# Altium schematic sheet SIm7600x.SchDoc(SIm7600x)
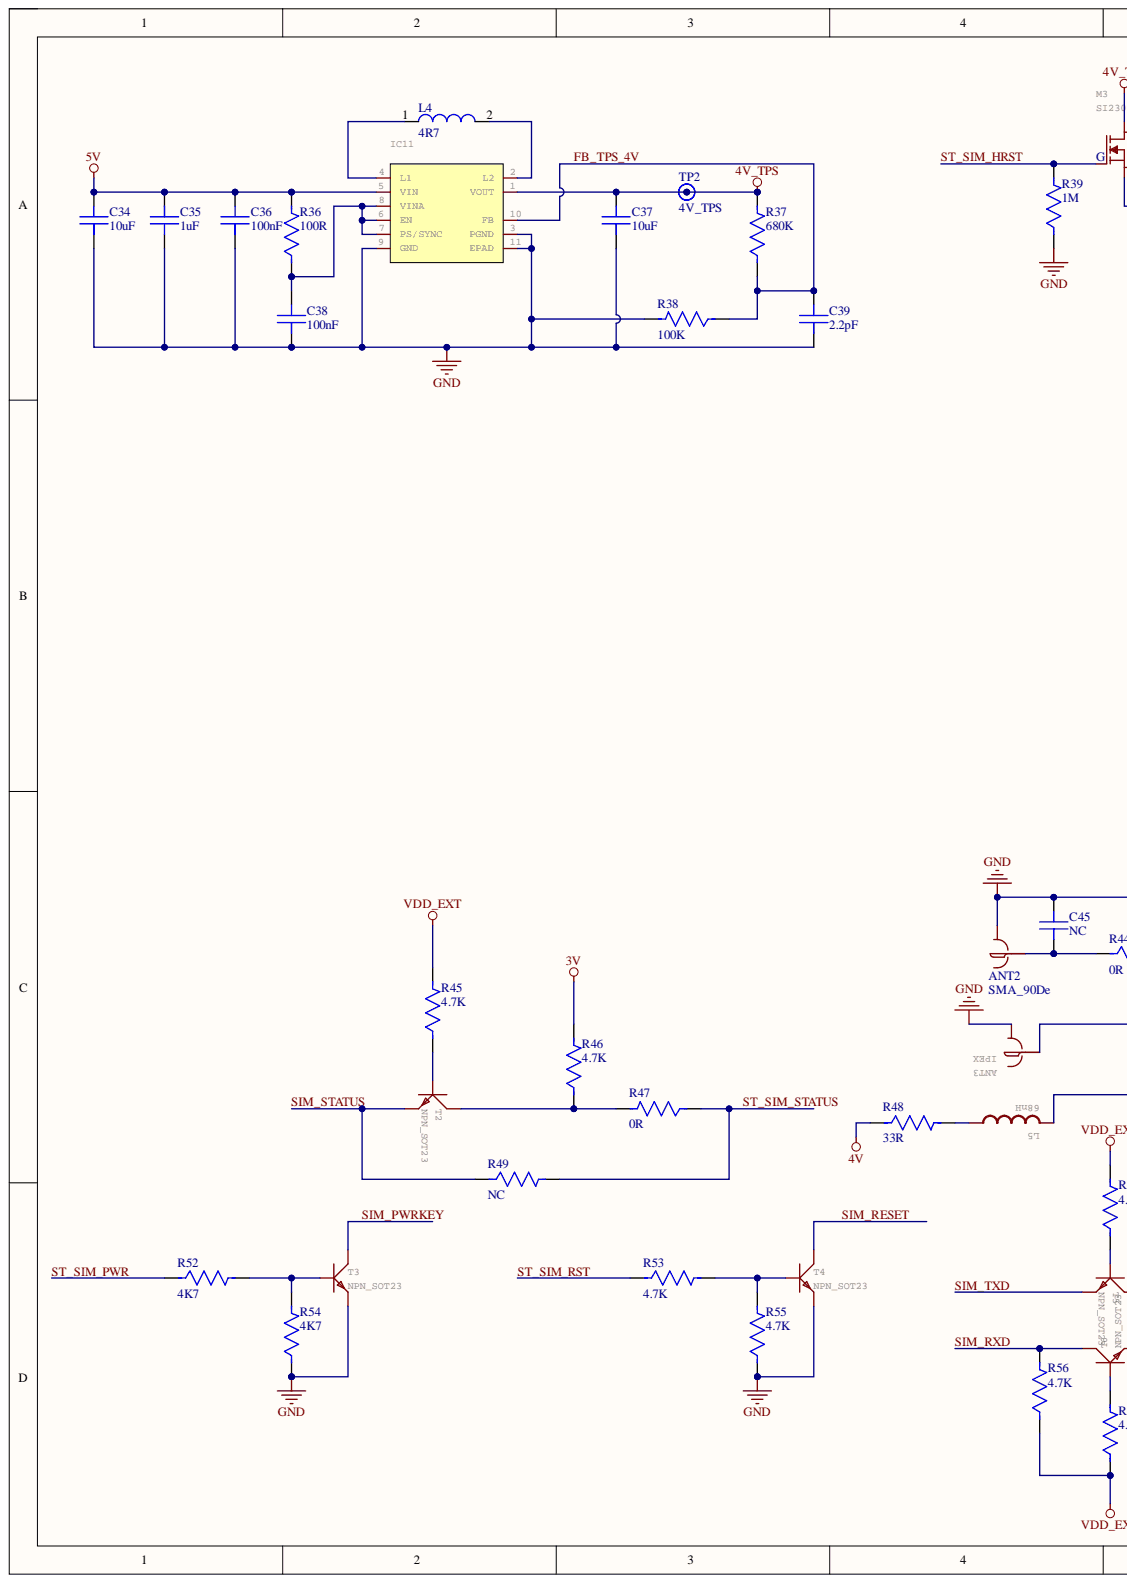
[diagram p0: SIm7600x.SchDoc, left]
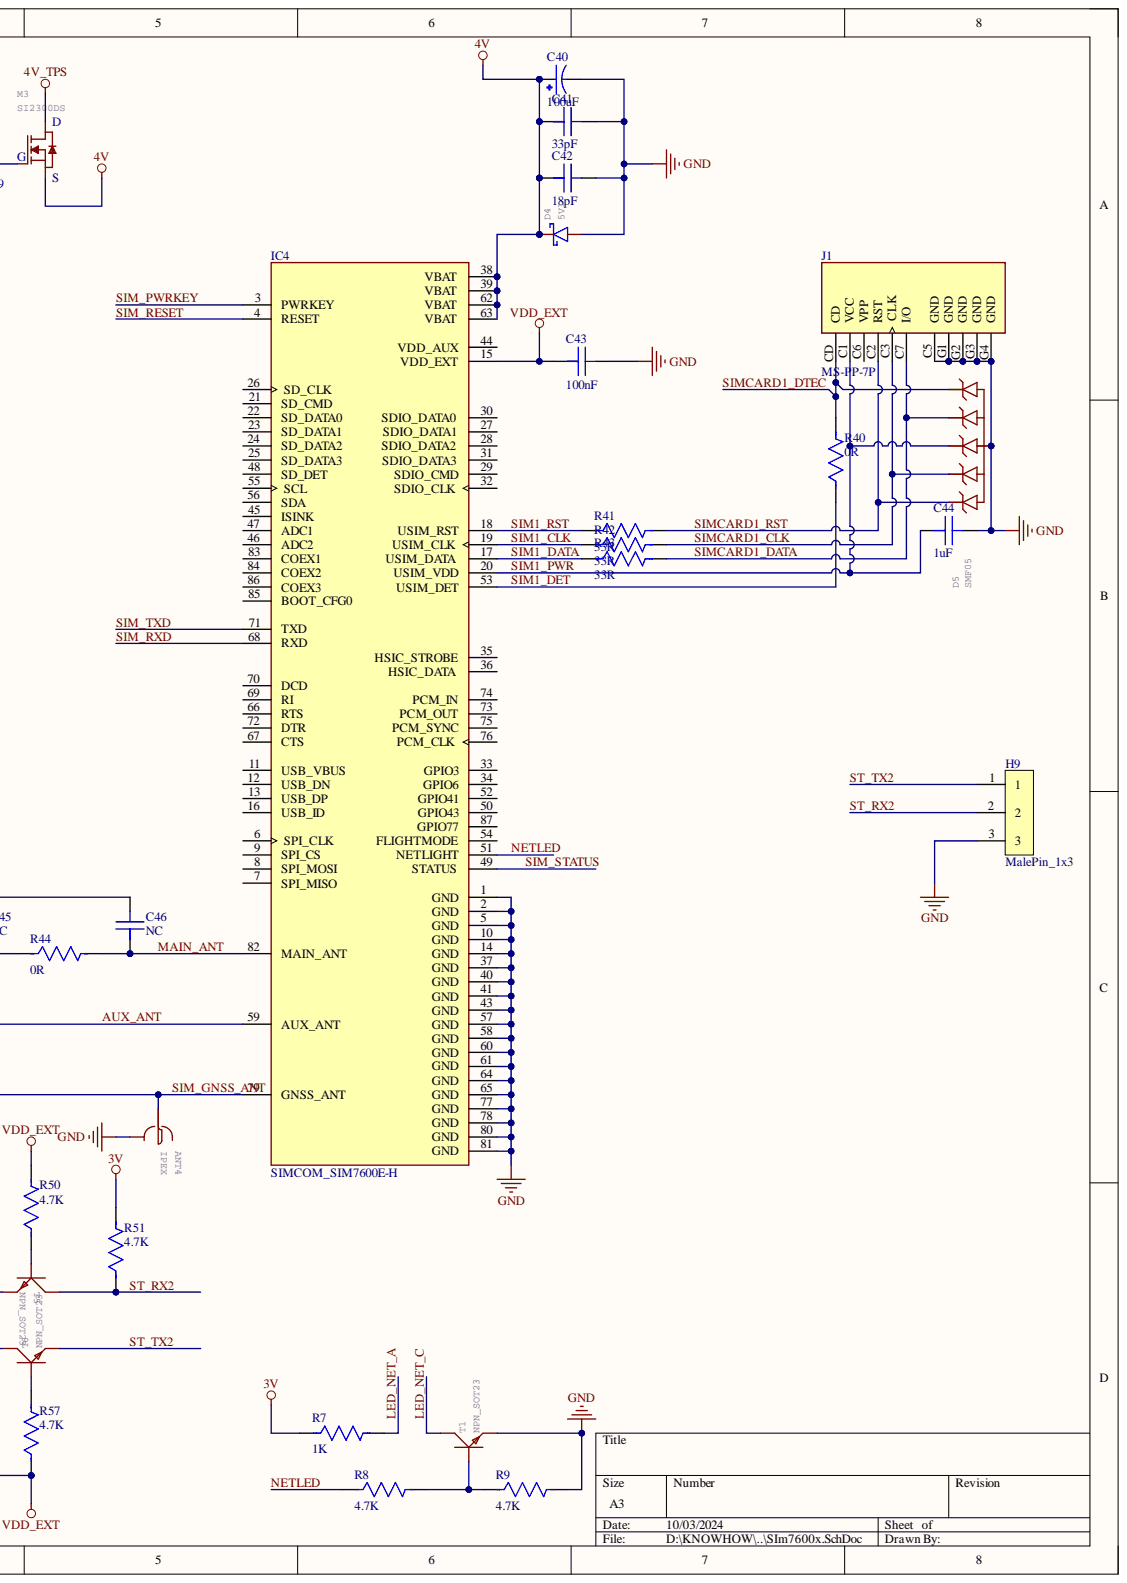
[diagram p1: SIm7600x.SchDoc, right]

## Parts
ANT2  SMA_90De  [SMA_90De]
ANT3  IPEX  [IPEX]
ANT4  IPEX  [IPEX]
C34  10uF  [C0603]
C35  1uF  [C0603]
C36  100nF  [C0603]
C37  10uF  [C0603]
C38  100nF  [C0603]
C39  2.2pF  [C0603]
C40  100uF  [1411P]
C41  33pF  [C0603]
C42  18pF  [C0603]
C43  100nF  [C0603]
C44  1uF  [C0603]
C45  NC  [C0603]
C46  NC  [C0603]
D4  5V1  [SOD123]
D5  SMF05  [SOT363]
H9  MalePin_1x3  [MALEPIN_1x3]
IC4  SIMCOM_SIM7600E-H  [SIMCOM_SIM7600E-H]
IC11  TPS63XXX  [VSON-10]  (value not drawn)
J1  MS-PP-7P  [GCT_SIM8065-6-1-14-01-A_REVA1]
L4  4R7  [L0420]
L5  68nH  [C0603]
M3  SI2300DS  [TO252-3]
R7  1K  [R0603]
R8  4.7K  [R0603]
R9  4.7K  [R0603]
R36  100R  [R0603]
R37  680K  [R0603]
R38  100K  [R0603]
R39  1M  [R0603]
R40  0R  [R0603]
R41  33R  [R0603]
R42  33R  [R0603]
R43  33R  [R0603]
R44  0R  [R0603]
R45  4.7K  [R0603]
R46  4.7K  [R0603]
R47  0R  [R0603]
R48  33R  [R0603]
R49  NC  [R0603]
R50  4.7K  [R0603]
R51  4.7K  [R0603]
R52  4K7  [R0603]
R53  4.7K  [R0603]
R54  4K7  [R0603]
R55  4.7K  [R0603]
R56  4.7K  [R0603]
R57  4.7K  [R0603]
T1  NPN_SOT23  [SOT23]
T2  NPN_SOT23  [SOT23]
T3  NPN_SOT23  [SOT23]
T4  NPN_SOT23  [SOT23]
T5  NPN_SOT23  [SOT23]
T6  NPN_SOT23  [SOT23]
TP2  4V_TPS  [TestPoint_1MM]

## Nets
3V: R7.1 R46.2 R51.2
4V: C40.1 C41.1 C42.1 D4.C IC4.38(VBAT) IC4.39(VBAT) IC4.62(VBAT) IC4.63(VBAT) M3.2 R48.1
4V_TPS: C37.2 IC11.1(VOUT) M3.3 R37.2 TP2.1
5V: C34.2 C35.1 C36.2 IC11.5(VIN) R36.2
GND: ANT2.2, 3, 4, 5 ANT3.2,3 ANT4.2,3 C34.1 C35.2 C36.1 C37.1 C38.1 C39.1 C40.2 C41.2 C42.2 C43.2 C44.2 C45.2 C46.2 D4.A D5.2 H9.3 IC4.1(GND) IC4.2(GND) IC4.5(GND) IC4.10(GND) IC4.14(GND) IC4.37(GND) IC4.40(GND) IC4.41(GND) IC4.43(GND) IC4.57(GND) IC4.58(GND) IC4.60(GND) IC4.61(GND) IC4.64(GND) IC4.65(GND) IC4.77(GND) IC4.78(GND) IC4.80(GND) IC4.81(GND) IC11.3(PGND) IC11.9(GND) IC11.11(EPAD) J1.C5(GND) J1.G1(GND) J1.G2(GND) J1.G3(GND) J1.G4(GND) R9.2 R38.2 R39.1 R54.1 R55.1 T1.2 T3.2 T4.2
VDD_EXT: C43.1 IC4.15(VDD_EXT) R45.2 R50.2 R56.1 R57.1
AUX_ANT: ANT3.1 IC4.59(AUX_ANT)
FB_TPS_4V: C39.2 IC11.10(FB) R37.1 R38.1
LED_NET_A: R7.2
LED_NET_C: T1.3
MAIN_ANT: C46.1 IC4.82(MAIN_ANT) R44.2
NETLED: IC4.51(NETLIGHT) R8.1
SIM1_CLK: IC4.19(USIM_CLK) R42.1
SIM1_DATA: IC4.17(USIM_DATA) R43.1
SIM1_DET: IC4.53(USIM_DET) R40.1
SIM1_PWR: C44.1 D5.4 IC4.20(USIM_VDD) J1.C1(VCC)
SIM1_RST: IC4.18(USIM_RST) R41.1
SIM_GNSS_ANT: ANT4.1 IC4.79(GNSS_ANT) L5.2
SIM_PWRKEY: IC4.3(PWRKEY) T3.3
SIM_RESET: IC4.4(RESET) T4.3
SIM_RXD: IC4.68(RXD) R56.2 T6.3
SIM_STATUS: IC4.49(STATUS) R49.1 T2.2
SIM_TXD: IC4.71(TXD) T5.2
SIMCARD1_CLK: D5.3 J1.C3(CLK) R42.2
SIMCARD1_DATA: D5.5 J1.C7(I/O) R43.2
SIMCARD1_DTEC: D5.6 J1.CD R40.2
SIMCARD1_RST: D5.1 J1.C2(RST) R41.2
ST_RX2: H9.2 R51.1 T5.3
ST_SIM_HRST: M3.1 R39.2
ST_SIM_PWR: R52.1
ST_SIM_RST: R53.1
ST_SIM_STATUS: R47.1 R49.2
ST_TX2: H9.1 T6.2
NetANT2_1: ANT2.1 C45.1 R44.1
NetC38_2: C38.2 IC11.6(EN) IC11.7(PS/SYNC) IC11.8(VINA) R36.1
NetIC11_2: IC11.2(L2) L4.2
NetIC11_4: IC11.4(L1) L4.1
NetL5_1: L5.1 R48.2
NetR8_2: R8.2 R9.1 T1.1
NetR45_1: R45.1 T2.1
NetR46_1: R46.1 R47.2 T2.3
NetR50_1: R50.1 T5.1
NetR52_2: R52.2 R54.2 T3.1
NetR53_2: R53.2 R55.2 T4.1
NetR57_2: R57.2 T6.1

Not connected: IC4 6(SPI_CLK) 7(SPI_MISO) 8(SPI_MOSI) 9(SPI_CS) 11(USB_VBUS) 12(USB_DN) 13(USB_DP) 16(USB_ID) 21(SD_CMD) 22(SD_DATA0) 23(SD_DATA1) 24(SD_DATA2) 25(SD_DATA3) 26(SD_CLK) 27(SDIO_DATA1) 28(SDIO_DATA2) 29(SDIO_CMD) 30(SDIO_DATA0) 31(SDIO_DATA3) 32(SDIO_CLK) 33(GPIO3) 34(GPIO6) 35(HSIC_STROBE) 36(HSIC_DATA) 44(VDD_AUX) 45(ISINK) 46(ADC2) 47(ADC1) 48(SD_DET) 50(GPIO43) 52(GPIO41) 54(FLIGHTMODE) 55(SCL) 56(SDA) 66(RTS) 67(CTS) 69(RI) 70(DCD) 72(DTR) 73(PCM_OUT) 74(PCM_IN) 75(PCM_SYNC) 76(PCM_CLK) 83(COEX1) 84(COEX2) 85(BOOT_CFG0) 86(COEX3) 87(GPIO77); J1 C6(VPP)

## Library data of the parts
ANT3 ANT4 = IPEX: GateName_1: G$1; SymbolName_1: ANTENNA; Component Kind: Standard; DeviceSetName: IPEX; Package: IPEX; Library Name: UY_Schlib.SchLib; LibraryName: Dkoi
C34-C39 C41-C46 = Capacitor 0603: Component Kind: Standard; Library Name: UY_Schlib.SchLib
C40 = CAP_TAN_1411: Aluminum Electrolytic Capacitors - Radial Leaded 50VDC 1200uF 10000H 16x25mm; MF: Panasonic; MP: EEU-FS1H122; Availability: In Stock; Check_prices: https://www.snapeda.com/parts/EEU-FS1H122/Panasonic/view-part/?ref=eda; Component Kind: Standard; Package: RADIAL-2 Panasonic; Price: None; Purchase-URL: https://pricing.snapeda.com/search/part/EEU-FS1H122/?ref=eda; SnapEDA_Link: https://www.snapeda.com/parts/EEU-FS1H122/Panasonic/view-part/?ref=snap; Library Name: UY_Schlib.SchLib
D4 = Zenner_SOD123: DeviceSetName: SS54F; GateName_1: G$1; SymbolName_1: DIODE_S; Component Kind: Standard; LibraryName: Uy_SMD; Package: SMAF; Library Name: UY_Schlib.SchLib
D5 = SMF05: GateName_1: G$1; Component Kind: Standard; DeviceSetName: SMF05; LibraryName: Uy_SMD; Package: SC7-6; SymbolName_1: SMF05; Library Name: UY_Schlib.SchLib
IC4 = SIMCOM_SIM7600E-H: Multi-Band LTE-TDD/LTE-FDD/HSPA+ and GSM/GPRS/EDGE module; Availability: Unavailable; Component Kind: Standard; MP: SIM7600E-H; Package: None; Price: None; Library Name: UY_Schlib.SchLib
IC11 = TPS63XXX: High-Efficient Single Inductor Buck-Boost Converter With 1.8A Switches; GateName_1: G$1; SymbolName_1: TPS6300X; Component Kind: Standard; DeviceSetName: TPS63XXX; LibraryName: Uy_SMD; Package: VSON-10; Library Name: UY_Schlib.SchLib
J1 = MS-PP-7P: Nano SIM Card Connector Push-Push Type, SMT, 6pin, 1.37mm Profile; MP: SIM8065-6-1-14-01-A_REVA1; Availability: Unavailable; Component Kind: Standard; Package: None; Price: None; Library Name: UY_Schlib.SchLib
L4 = Inductor 0420: Component Kind: Standard; Library Name: UY_Schlib.SchLib
L5 = Inductor 0603: DeviceSetName: L0603; GateName_1: G$1; SymbolName_1: L; Component Kind: Standard; LibraryName: Uy_SMD; Package: 0603; Library Name: UY_Schlib.SchLib
M3 = MOSFETN_TO252: SOT-23; GateName_1: G$1; SymbolName_1: MOSFET_N; Component Kind: Standard; DeviceSetName: MOSFETN; LibraryName: Uy_SMD; Package: SOT23(1); Library Name: UY_Schlib.SchLib
R7-R9 R36-R57 = Resistor 0603: SMD Resistor 0603; Manufacturer: Yageo; Manufacturer Part Number: RC0603FR-071KL; Max Power Dissipation: 100mW; Resistance Tolerance: 1%; Temperature Coefficient: 100ppm/°C; Voltage Rating: 50V; Case Code (Imperial): 0603; Case Code (Metric): 1608; Case/Package: 0603; Category: Chip SMD Resistors; Component Kind: Standard; Construction: Rectangular; Contact Plating: Tin,Matte; Depth: 0.8mm; Features: Moisture Resistant; Height: 0.45mm; Lead Free: 5697LeadFree; Length: 1.6mm; Max Operating Temperature: 155°C; Military Standard: Not; Min Operating Temperature: -55°C; Number of Terminations: 2; Packaging: Tape and Reel; Power Rating: 100mW; Pricing: 1=0.1, 10=0.018, 50=0.0106, 100=0.0088, 500=0.00592, 1000=0.00505 (USD); Reach SVHC Compliant: No; Resistor Type: Thick Film; RoHS: Yes; RoHS Compliant: Yes; Series: RC; Stock: 18662657; Supplier: Digi-Key; Supplier Part Number: 311-1.00KHRDKR-ND; Technology: THICK FILM; Termination: SMD/SMT; Tolerance: 1%; Library Name: UY_Schlib.SchLib
T1-T6 = NPN_SOT23: BJT SOT23; DeviceSetName: NPN; GateName_1: G$1; Component Kind: Standard; LibraryName: Uy_SMD; Package: SOT23(1); SymbolName_1: NPN; Library Name: UY_Schlib.SchLib
TP2 = TestPoint_1MM: Component Kind: Standard; Library Name: UY_Schlib.SchLib
ANT2 = SMA_90De: Component Kind: Standard; Library Name: UY_Schlib.SchLib
H9 = MalePin_1x3: Component Kind: Standard; Library Name: UY_MalePin2.54.SchLib
